# Altium schematic sheet turret_board.SchDoc
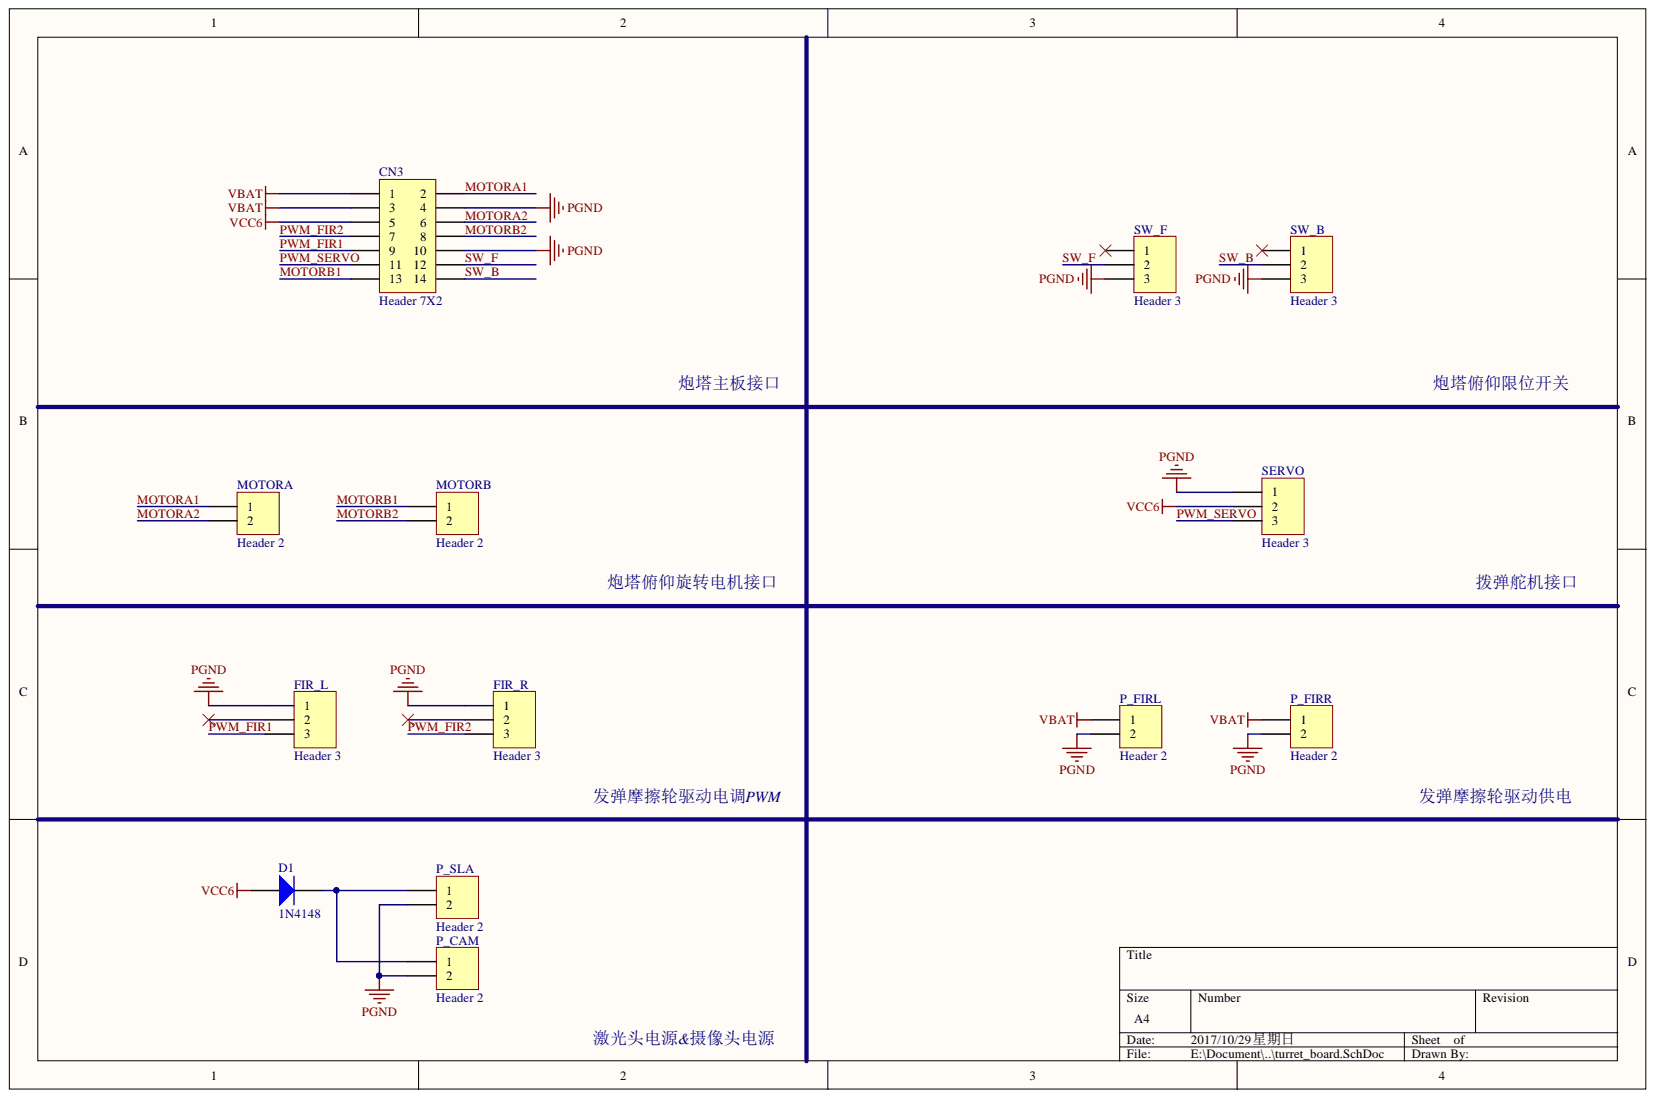
[diagram p0: turret_board.SchDoc]

## Parts
CN3  Header 7X2  [DC3-14P-2.0mm]
D1  1N4148  [D-1206]
FIR_L  Header 3  [HDR3X1-2.54]
FIR_R  Header 3  [HDR3X1-2.54]
MOTORA  Header 2  [SH1.25-2p-zhicha]
MOTORB  Header 2  [SH1.25-2p-zhicha]
P_CAM  Header 2  [POWER_PAD_2]
P_FIRL  Header 2  [POWER_PAD_1]
P_FIRR  Header 2  [POWER_PAD_1]
P_SLA  Header 2  [POWER_PAD_2]
SERVO  Header 3  [HDR3X1-2.54]
SW_B  Header 3  [SH1.25-3p-zhicha]
SW_F  Header 3  [SH1.25-3p-zhicha]

## Nets
PGND: CN3.4 CN3.10 FIR_L.1 FIR_R.1 P_CAM.2 P_FIRL.2 P_FIRR.2 P_SLA.2 SERVO.1 SW_B.3 SW_F.3
VBAT: CN3.1 CN3.3 P_FIRL.1 P_FIRR.1
VCC6: CN3.5 D1.1 SERVO.2
MOTORA1: CN3.2 MOTORA.1
MOTORA2: CN3.6 MOTORA.2
MOTORB1: CN3.13 MOTORB.1
MOTORB2: CN3.8 MOTORB.2
PWM_FIR1: CN3.9 FIR_L.3
PWM_FIR2: CN3.7 FIR_R.3
PWM_SERVO: CN3.11 SERVO.3
SW_B: CN3.14 SW_B.2
SW_F: CN3.12 SW_F.2
NetD1_2: D1.2 P_CAM.1 P_SLA.1

Not connected: FIR_L 2; FIR_R 2; SW_B 1; SW_F 1

## Library data of the parts
CN3 = Header 7X2: Header, 7-Pin, Dual row; Component Kind: Standard; LatestRevisionDate: 17-Jul-2002; LatestRevisionNote: Re-released for DXP Platform.; Library Name: Miscellaneous Connectors.IntLib; Publisher: Altium Limited
D1 = Diode: 二极管; Code_JEDEC: DO-214-AB; Component Kind: Standard; LatestRevisionDate: 17-Jul-2002; LatestRevisionNote: Re-released for DXP Platform.; PackageDocument: Sep-1996; PackageReference: SMC; Published: 8-Jun-2000; Library Name: Fszhang.IntLib; Publisher: Altium Limited
FIR_L FIR_R SERVO SW_B SW_F = Header 3: Header, 3-Pin; Component Kind: Standard; LatestRevisionDate: 17-Jul-2002; LatestRevisionNote: Re-released for DXP Platform.; Library Name: Miscellaneous Connectors.IntLib; Publisher: Altium Limited
MOTORA MOTORB P_CAM P_FIRL P_FIRR P_SLA = Header 2: Header, 2-Pin; Component Kind: Standard; LatestRevisionDate: 17-Jul-2002; LatestRevisionNote: Re-released for DXP Platform.; Library Name: Miscellaneous Connectors.IntLib; Publisher: Altium Limited
